# Altium schematic sheet 05021002_GPIO's.SchDoc(05021002_GPIO's)
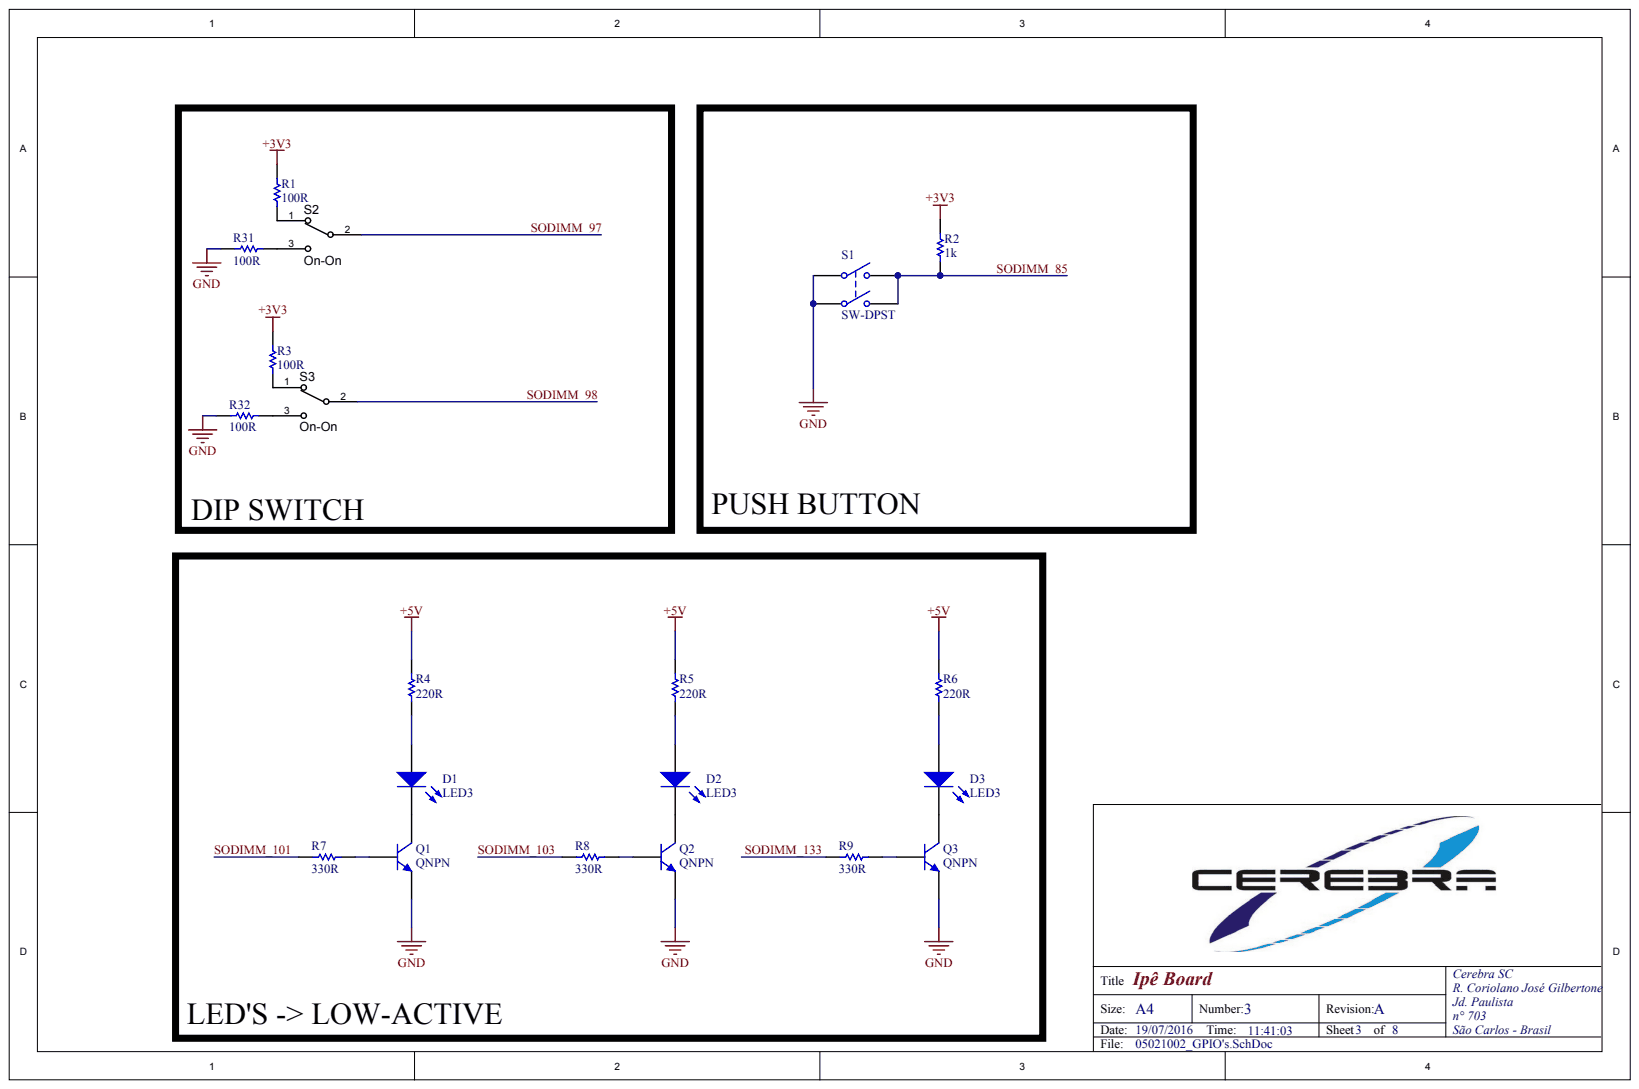
[diagram p0: 05021002_GPIO's.SchDoc]

## Parts
D1  LED3  [LED-0805-K]
D2  LED3  [LED-0805-K]
D3  LED3  [LED-0805-K]
Q1  QNPN  [SOT-23]
Q2  QNPN  [SOT-23]
Q3  QNPN  [SOT-23]
R1  100R  [0805]
R2  1k  [0805]
R3  100R  [0805]
R4  220R  [0805]
R5  220R  [0805]
R6  220R  [0805]
R7  330R  [0805]
R8  330R  [0805]
R9  330R  [0805]
R31  100R  [0805]
R32  100R  [0805]
S1  SW-DPST  [430182080816]
S2  ON-ON  [SWITCH_SPDT_SLIDER]
S3  ON-ON  [SWITCH_SPDT_SLIDER]

## Nets
+3V3: R1.2 R2.2 R3.2
+5V: R4.2 R5.2 R6.2
GND: Q1.3 Q2.3 Q3.3 R31.2 R32.2 S1.1 S1.3
SODIMM_85: R2.1 S1.2 S1.4
SODIMM_97: S2.2
SODIMM_98: S3.2
SODIMM_101: R7.1
SODIMM_103: R8.1
SODIMM_133: R9.1
NetD1_1: D1.1 R4.1
NetD1_2: D1.2 Q1.1
NetD2_1: D2.1 R5.1
NetD2_2: D2.2 Q2.1
NetD3_1: D3.1 R6.1
NetD3_2: D3.2 Q3.1
NetQ1_2: Q1.2 R7.2
NetQ2_2: Q2.2 R8.2
NetQ3_2: Q3.2 R9.2
NetR1_1: R1.1 S2.1
NetR3_1: R3.1 S3.1
NetR31_1: R31.1 S2.3
NetR32_1: R32.1 S3.3

## Library data of the parts
D1-D3 = LED3: Typical BLUE SiC LED; Manufacturer 1: OSRAM Opto Semiconductors Inc.; Part Number 1: LG R971-KN-1; Component Kind: Standard; DatasheetVersion: 16-Apr-2002; LatestRevisionDate: 17-Dec-2003; LatestRevisionNote: PCB Footprint replaced.; Library Name: Miscellaneous Devices.IntLib; PackageDescription: SM LED; 2 C-Bend Leads; Body 3.5 x 2.8 x 1.9 mm, inc leads (LxWxH typ); PackageReference: 3.5X2.8X1.9; PackageVersion: 16-Apr-2002; Published: 8-Jun-2000; Signal Integrity: LED; Simulation: LED3; Publisher: Altium Limited
Q1-Q3 = QNPN: NPN Bipolar Transistor; Manufacturer 1: Diodes Incorporated; Part Number 1: MMBT2222A-7-F; Component Kind: Standard; LatestRevisionDate: 29-May-2009; LatestRevisionNote: IPC-7351 Footprint Added.; Library Name: Miscellaneous Devices.IntLib; PackageDescription: 3-Pin SOT-23 Package 0.95 mm Pitch, 2.4 mm Lead Span; PackageReference: SOT-23B; PackageVersion: Aug-1999; Published: 8-Jun-2000; Signal Integrity: NPN; Simulation: QNPN; Publisher: Altium Limited
R1 R3 R31 R32 = resistor_gen: Manufacturer 1: Stackpole Electronics Inc.; Part Number 1: RMCF0805JT100R; Component Kind: Standard; Library Name: resistor_smd_gen.SchLib; Value: 100R
R2 = resistor_gen: Manufacturer 1: Yageo; Part Number 1: RC0805JR-071KL; Component Kind: Standard; Library Name: resistor_smd_gen.SchLib; Value: 1k
R4-R6 = resistor_gen: Manufacturer 1: Stackpole Electronics Inc.; Part Number 1: RMCF0805JT220R; Component Kind: Standard; Library Name: resistor_smd_gen.SchLib; Value: 220R
R7-R9 = resistor_gen: Manufacturer 1: Stackpole Electronics Inc.; Part Number 1: RMCF0805JT330R; Component Kind: Standard; Library Name: resistor_smd_gen.SchLib; Value: 330R
S1 = SW-DPST: Double-Pole, Single-Throw Switch; Manufacturer 1: Wurth Electronics Inc; Component Kind: Standard; LatestRevisionDate: 17-Jul-2002; LatestRevisionNote: Re-released for DXP Platform.; Library Name: Miscellaneous Devices.IntLib; PackageDescription: Switch; 4 Leads; PackageReference: DPST-4; Part Number 1: 430182080816; Published: 24-Mar-1999; Publisher: Altium Limited
S2 S3 = SW_THD_SPDT_On-On: Switch THD vertical toggle SPDT; Manufacturer 1: TE Connectivity; Part Number 1: SLS121PC04; Contact Rating @ Voltage: 0.25A @ 125VAC; SCDType: spec; Circuit: SPDT; Component Kind: Standard; Library Name: PBL - Switches.SCHLIB; Mounting Type: PCB mount; Supplier 1: Digi-Key; Supplier Part Number 1: 450-1598-ND; Switch Function: On-On
